# Altium schematic sheet Arduino.SchDoc(Arduino)
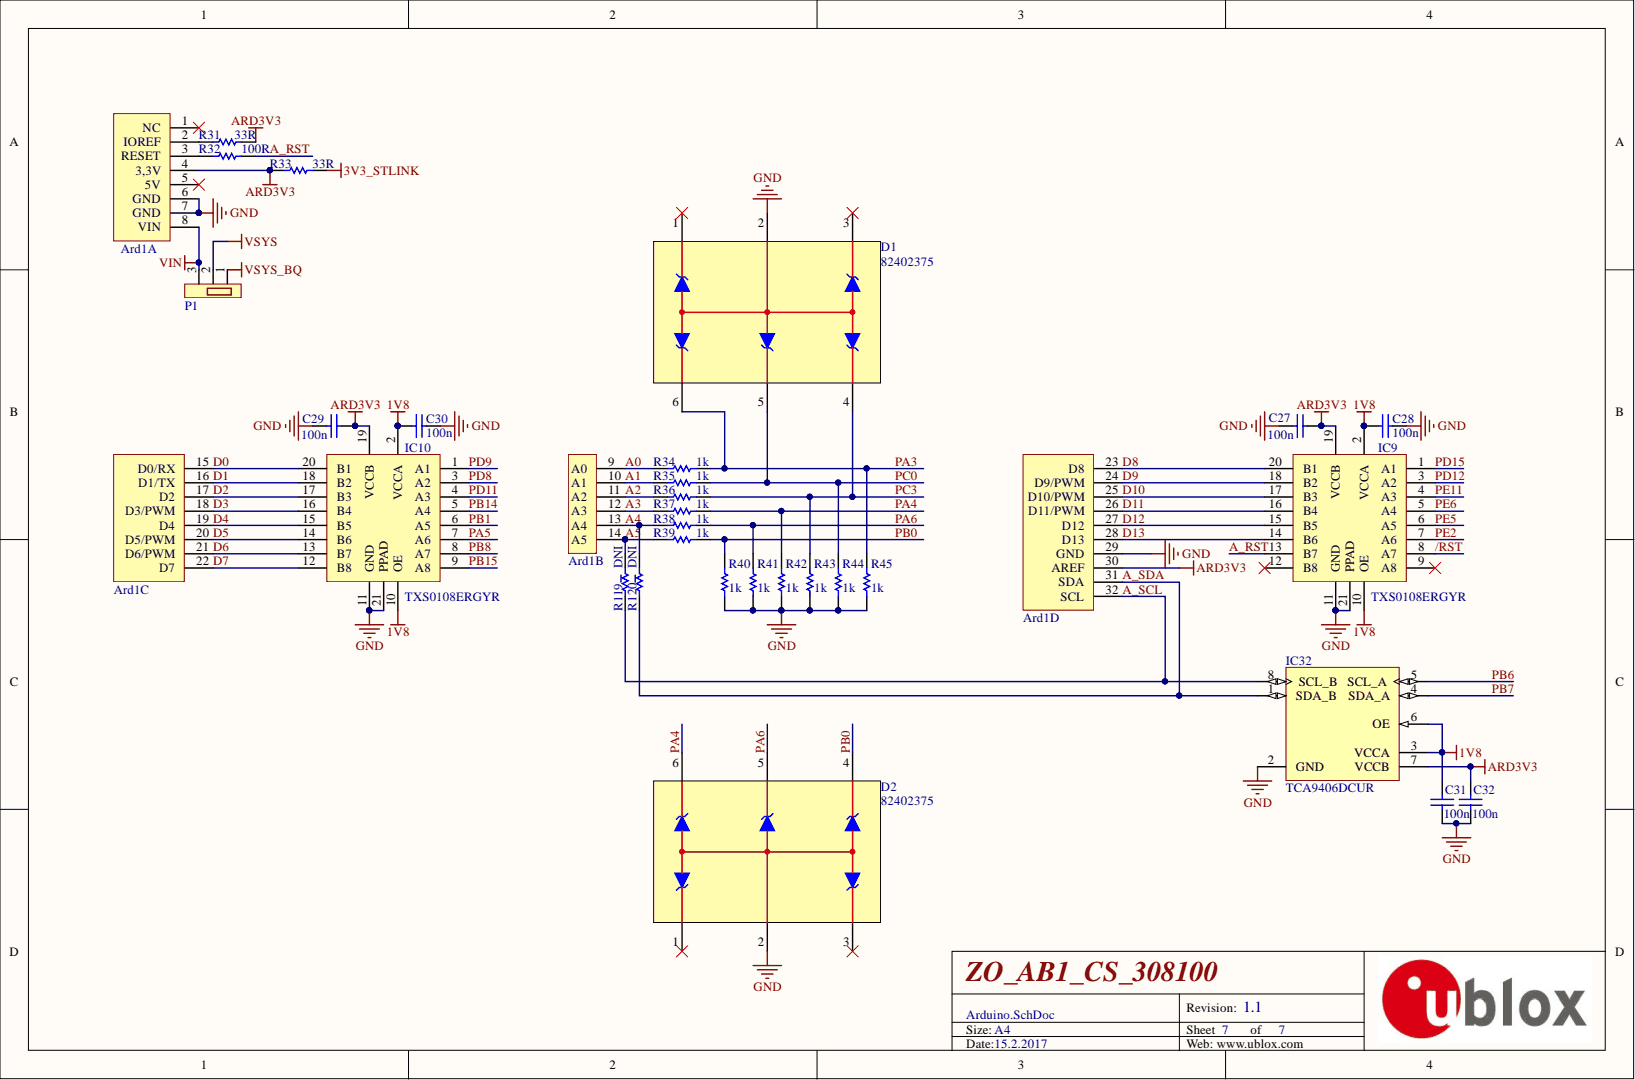
[diagram p0: Arduino.SchDoc]

## Parts
Ard1  ESQ-106-24-T-S 1pc. +  ESQ-110-24-T-S 1pc. +  ESQ-108-24-T-S 2pcs.  [Arduino header]  (value not drawn)  (parts A,B,C,D)
C27  100n  [C0402]
C28  100n  [C0402]
C29  100n  [C0402]
C30  100n  [C0402]
C31  100n  [C0402]
C32  100n  [C0402]
D1  82402375  [SC70-6L]
D2  82402375  [SC70-6L]
IC9  TXS0108ERGYR  [RGY QFN50P450X350X100_HS-21L]
IC10  TXS0108ERGYR  [RGY QFN50P450X350X100_HS-21L]
IC32  TCA9406DCUR  [DCU8_L]
P1  ZL201-03G  [Header-J 1X3]  (value not drawn)
R31  33R  [R0402]
R32  100R  [R0402]
R33  33R  [R0402]
R34  1k  [R0402]
R35  1k  [R0402]
R36  1k  [R0402]
R37  1k  [R0402]
R38  1k  [R0402]
R39  1k  [R0402]
R40  1k  [R0402]
R41  1k  [R0402]
R42  1k  [R0402]
R43  1k  [R0402]
R44  1k  [R0402]
R45  1k  [R0402]
R119  DNI  [R0603pacman]
R120  DNI  [R0603pacman]

## Nets
1V8: C28.2 C30.2 C31.2 IC9.2(VCCA) IC9.10(OE) IC10.2(VCCA) IC10.10(OE) IC32.3(VCCA) IC32.6(OE)
3V3_STLINK: R33.2
ARD3V3: Ard1.4(3,3V) Ard1.30(AREF) C27.1 C29.1 C32.2 IC9.19(VCCB) IC10.19(VCCB) IC32.7(VCCB) R31.2 R33.1
GND: Ard1.6(GND) Ard1.7(GND) Ard1.29(GND) C27.2 C28.1 C29.2 C30.1 C31.1 C32.1 D1.2 D2.2 IC9.11(GND) IC9.21(PPAD) IC10.11(GND) IC10.21(PPAD) IC32.2(GND) R40.2 R41.2 R42.2 R43.2 R44.2 R45.2
VIN: Ard1.8(VIN) P1.3
VSYS: P1.2
VSYS_BQ: P1.1
/RST: IC9.8(A7)
A0: Ard1.9(A0) R34.1
A1: Ard1.10(A1) R35.1
A2: Ard1.11(A2) R36.1
A3: Ard1.12(A3) R37.1
A4: Ard1.13(A4) R38.1 R120.2
A5: Ard1.14(A5) R39.1 R119.2
A_RST: IC9.13(B7) R32.2
A_SCL: Ard1.32(SCL) IC32.8(SCL_B) R119.1
A_SDA: Ard1.31(SDA) IC32.1(SDA_B) R120.1
D0: Ard1.15(D0/RX) IC10.20(B1)
D1: Ard1.16(D1/TX) IC10.18(B2)
D2: Ard1.17(D2) IC10.17(B3)
D3: Ard1.18(D3/PWM) IC10.16(B4)
D4: Ard1.19(D4) IC10.15(B5)
D5: Ard1.20(D5/PWM) IC10.14(B6)
D6: Ard1.21(D6/PWM) IC10.13(B7)
D7: Ard1.22(D7) IC10.12(B8)
D8: Ard1.23(D8) IC9.20(B1)
D9: Ard1.24(D9/PWM) IC9.18(B2)
D10: Ard1.25(D10/PWM) IC9.17(B3)
D11: Ard1.26(D11/PWM) IC9.16(B4)
D12: Ard1.27(D12) IC9.15(B5)
D13: Ard1.28(D13) IC9.14(B6)
PA3: D1.6 R34.2 R45.1
PA4: D2.6 R37.2 R42.1
PA5: IC10.7(A6)
PA6: D2.5 R38.2 R41.1
PB0: D2.4 R39.2 R40.1
PB1: IC10.6(A5)
PB6: IC32.5(SCL_A)
PB7: IC32.4(SDA_A)
PB8: IC10.8(A7)
PB14: IC10.5(A4)
PB15: IC10.9(A8)
PC0: D1.5 R35.2 R44.1
PC3: D1.4 R36.2 R43.1
PD8: IC10.3(A2)
PD9: IC10.1(A1)
PD11: IC10.4(A3)
PD12: IC9.3(A2)
PD15: IC9.1(A1)
PE2: IC9.7(A6)
PE5: IC9.6(A5)
PE6: IC9.5(A4)
PE11: IC9.4(A3)
NetArd1_2: Ard1.2(IOREF) R31.1
NetArd1_3: Ard1.3(RESET) R32.1

Not connected: Ard1 1(NC) 5(5V); D1 1 3; D2 1 3; IC9 9(A8) 12(B8)

## Library data of the parts
Ard1 = Arduino header: Component Kind: Standard; Description: Arduino header; Library Name: BorisHeaders.SchLib
C27-C32 = Capacitor SMD: SMD chip capacitor; Value: Xn; Component Kind: Standard; LatestRevisionDate: 26-Dec-2008; Published: 26-Dec-2008; Signal Integrity: Cap; Simulation: CAP; Library Name: BorisPassive.SchLib; Publisher: www.poscope.com
D1 D2 = WE-TVS, SC70-6L, 82402375: WE-TVS TVS Diode, Standard Series, SC70-6L, VDC = 5V; Manufacturer: Wurth Elektronik; Component Kind: Standard; ComponentLink1Description: Manufacturer; ComponentLink1URL: http://www.we-online.de; ComponentLink2Description: Datasheet; ComponentLink2URL: http://katalog.we-online.de/pbs/datasheet/82402375.pdf; Created Date: Jan 2016; Library Name: ALTIUM-WE-TVS-rev16a.IntLib; PartNo: 82402375; VDC: 5V; Created by: BJ.Chen
IC9 IC10 = TXS0108ERGYR: 8-Bit Bi-directional, Level-Shifting, Voltage Translator  for Open-Drain and Push-Pull Applications; Component Kind: Standard; Library Name: BorisIC1.SchLib
IC32 = TCA9406DCUR: TCA9406 Dual Bidirectional 1-MHz I2C-BUS and SMBus Voltage Level-Translator, 1.65 to 3.6 V, -40 to 85 degC, 8-pin US8 (DCU), Green (RoHS & no Sb/Br); Manufacturer: Texas Instruments; 5V Tolerant I/O: Yes; Function: I2C Voltage Level Translator; Max Frequency(kHz): 1000; No. of Outputs: 2; Rating: Catalog; SubFamily: I2C Special Function; VCC(Max)(V): 4.6; VCC(Min)(V): -0.5; Code_JEDEC: MO-187-CA; Component Kind: Standard; ComponentLink1Description: Datasheet; ComponentLink1URL: http://www.ti.com/general/docs/lit/getliterature.tsp?genericPartNumber=TCA9406&fileType=pdf; ComponentLink2Description: Package Specification; ComponentLink2URL: http://www.ti.com/litv/pdf/mpds050d; DatasheetVersion: SCPS221; Eco-Plan: Green (RoHS & no Sb/Br); Enable Pin: Yes; Library Name: Texas Instruments Interface I2C.IntLib; Mounting Technology: Surface Mount; On-Chip Power-on-Reset: No; Open Drain I/O Type: Yes; PackageDescription: 8-Pin Thin Shrink Small Outline Package 0.5 mm Pitch; PackageReference: DCU8; PackageVersion: revF, Jul-2005; PartNumber: TCA9406DCUR; Push-Pull I/O Type: Yes; Supplier 1: Digi-Key; Supplier 2: Digi-Key; Supplier 3: Digi-Key; Supplier 4: Farnell; Supplier 5: Newark; Supplier 6: Newark; Supplier 7: Mouser; Supplier Part Number 1: 296-28109-2-ND; Supplier Part Number 2: 296-28109-1-ND; Supplier Part Number 3: 296-28109-6-ND; Supplier Part Number 4: 1972385; Supplier Part Number 5: 59T0098; Supplier Part Number 6: 24T4760; Supplier Part Number 7: 595-TCA9406DCUR; Vcc range(V): 1.65 to 3.6
P1 = Header J 1x3: Component Kind: Standard; Description: Header J 1x3; Library Name: BorisCon.SchLib
R31-R45 = Resistor SMD: SMD chip resistor; Value: Xk; Component Kind: Standard; LatestRevisionDate: 26-Dec-2008; Published: 26-Dec-2008; Signal Integrity: Res Semi; Simulation: RES; Library Name: BorisPassive.SchLib; Publisher: www.poscope.com
R119 R120 = Resistor Pacman SMD: SMD chip resistor with Payman for easy soldering; Value: Xk; Component Kind: Standard; LatestRevisionDate: 26-Dec-2008; Published: 26-Dec-2008; Signal Integrity: Res Semi; Simulation: RES; Library Name: BorisPassive.SchLib; Publisher: www.poscope.com
